# Altium schematic sheet ESP32_main.SchDoc
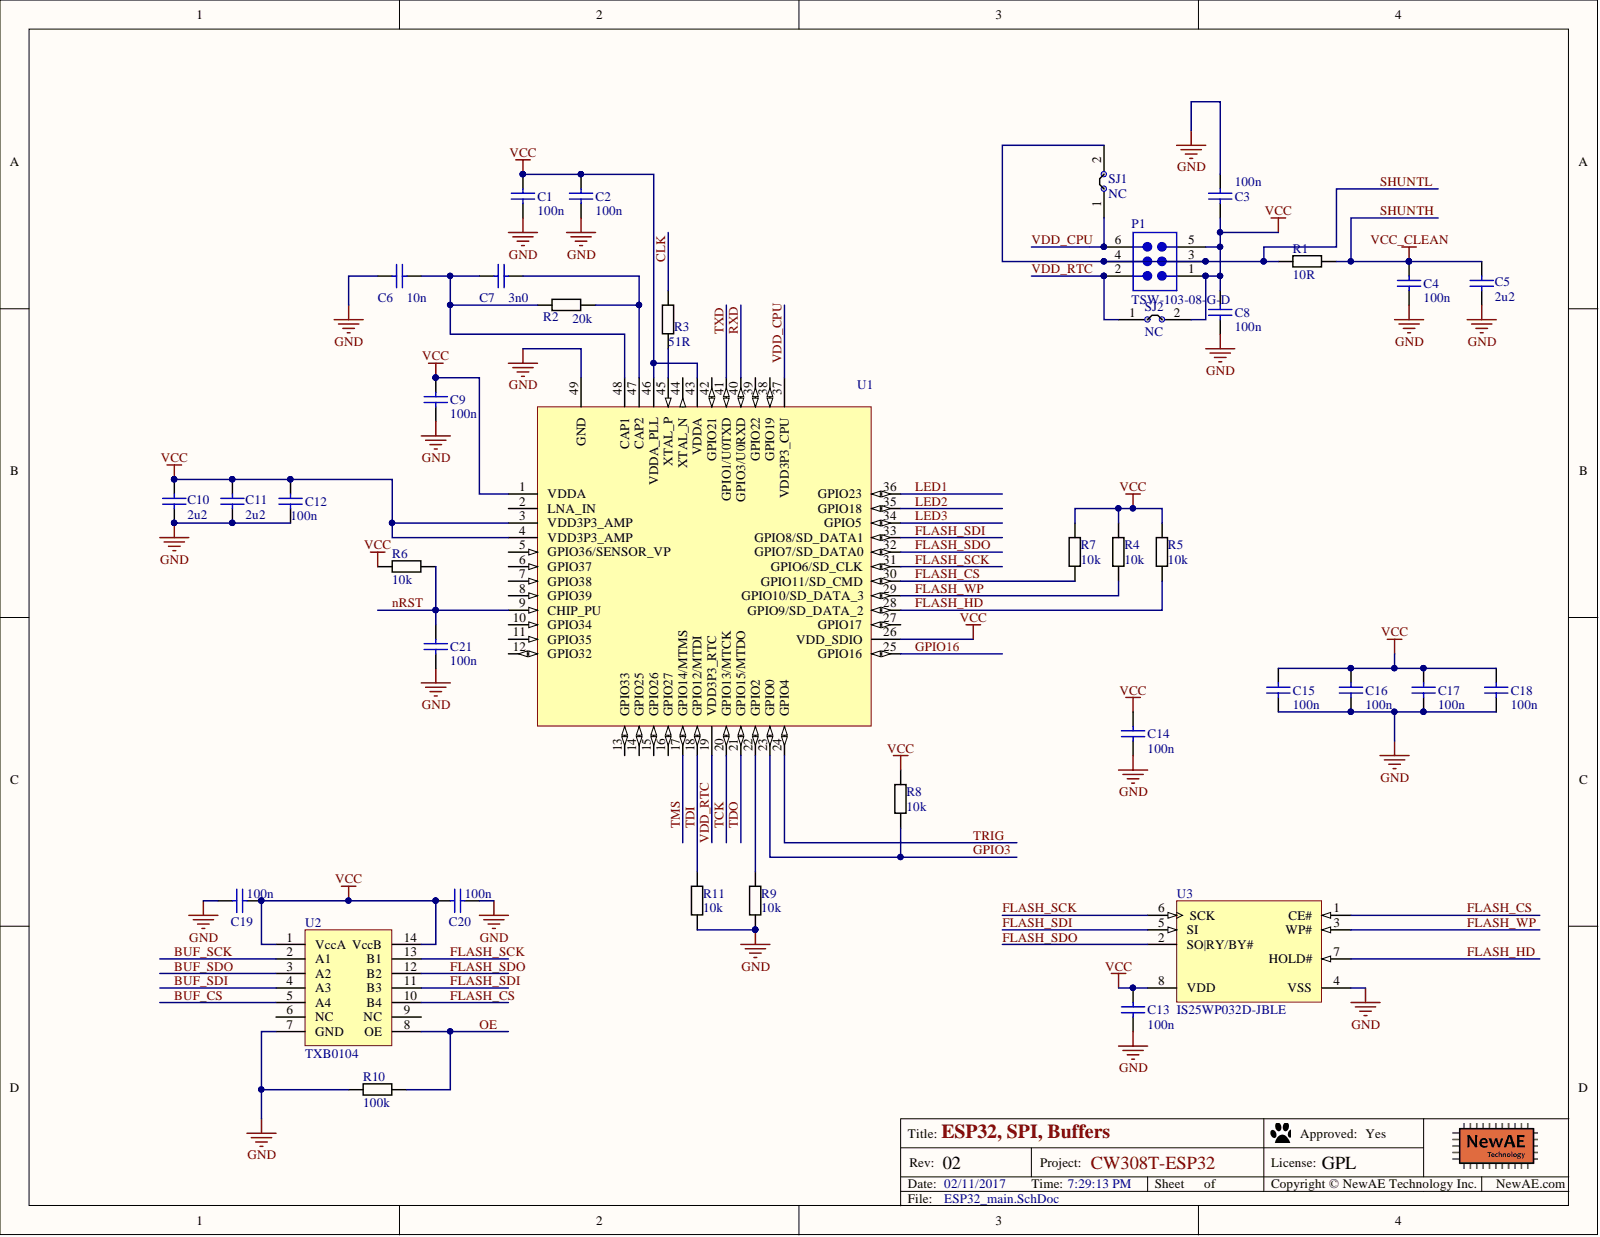
[diagram p0: ESP32_main.SchDoc]

## Parts
C1  100n  [0603]
C2  100n  [0603]
C3  100n  [0603]
C4  100n  [0603]
C5  2u2  [0603]
C6  10n  [0603]
C7  3n0  [0603]
C8  100n  [0603]
C9  100n  [0603]
C10  2u2  [0603]
C11  2u2  [0603]
C12  100n  [0603]
C13  100n  [0603]
C14  100n  [0603]
C15  100n  [0603]
C16  100n  [0603]
C17  100n  [0603]
C18  100n  [0603]
C19  100n  [0603]
C20  100n  [0603]
C21  100n  [0603]
P1  TSW-103-08-G-D  [SMTC-TSW-103-08-X-D]
R1  10R  [0603]
R2  20k  [0603]
R3  51R  [0603]
R4  10k  [0603]
R5  10k  [0603]
R6  10k  [0603]
R7  10k  [0603]
R8  10k  [0603]
R9  10k  [0603]
R10  100k  [0603]
R11  10k  [0603]
SJ1  NC  [SJ_2S_NC]  (no BOM)
SJ2  NC  [SJ_2S_NC]  (no BOM)
U1  ESP32  [QFN48_HAND]  (value not drawn)
U2  TXB0104  [SOIC14 (R-PDSO-G14)]
U3  IS25WP032D-JBLE  [SOIC8_WIDE]

## Nets
GND: C1.2 C2.2 C3.1 C4.2 C5.2 C6.1 C8.2 C9.2 C10.2 C11.2 C12.2 C13.2 C14.2 C15.2 C16.2 C17.2 C18.2 C19.1 C20.2 C21.2 R9.2 R10.1 R11.2 U1.49(GND) U2.7(GND) U3.4(VSS)
VCC: C1.1 C2.1 C3.2 C8.1 C9.1 C10.1 C11.1 C12.1 C13.1 C14.1 C15.1 C16.1 C17.1 C18.1 C19.2 C20.1 P1.1 P1.5 R4.1 R5.1 R6.1 R7.1 R8.1 SJ2.2 U1.1(VDDA) U1.3(VDD3P3_AMP) U1.4(VDD3P3_AMP) U1.26(VDD_SDIO) U1.43(VDDA) U1.46(VDDA_PLL) U2.1(VccA) U2.14(VccB) U3.8(VDD)
BUF_CS: U2.5(A4)
BUF_SCK: U2.2(A1)
BUF_SDI: U2.4(A3)
BUF_SDO: U2.3(A2)
CLK: R3.1
FLASH_CS: R7.2 U1.30(GPIO11/SD_CMD) U2.10(B4) U3.1(CE#)
FLASH_HD: R5.2 U1.28(GPIO9/SD_DATA_2) U3.7(HOLD#)
FLASH_SCK: U1.31(GPIO6/SD_CLK) U2.13(B1) U3.6(SCK)
FLASH_SDI: U1.33(GPIO8/SD_DATA1) U2.11(B3) U3.5(SI)
FLASH_SDO: U1.32(GPIO7/SD_DATA0) U2.12(B2) U3.2(SO|RY/BY#)
FLASH_WP: R4.2 U1.29(GPIO10/SD_DATA_3) U3.3(WP#)
GPIO3: R8.2 U1.23(GPIO0)
GPIO16: U1.25(GPIO16)
LED1: U1.36(GPIO23)
LED2: U1.35(GPIO18)
LED3: U1.34(GPIO5)
nRST: C21.1 R6.2 U1.9(CHIP_PU)
OE: R10.2 U2.8(OE)
RXD: U1.40(GPIO3/U0RXD)
SHUNTH: C4.1 C5.1 R1.2
SHUNTL: P1.3 P1.4 R1.1 SJ1.2
TCK: U1.20(GPIO13/MTCK)
TDI: R11.1 U1.18(GPIO12/MTDI)
TDO: U1.21(GPIO15/MTDO)
TMS: U1.17(GPIO14/MTMS)
TRIG: U1.24(GPIO4)
TXD: U1.41(GPIO1/U0TXD)
VDD_CPU: P1.6 SJ1.1 U1.37(VDD3P3_CPU)
VDD_RTC: P1.2 SJ2.1 U1.19(VDD3P3_RTC)
NetC6_2: C6.2 C7.1 R2.1 U1.48(CAP1)
NetC7_2: C7.2 R2.2 U1.47(CAP2)
NetR3_2: R3.2 U1.45(XTAL_P)
NetR9_1: R9.1 U1.22(GPIO2)

Not connected: U1 2(LNA_IN) 5(GPIO36/SENSOR_VP) 6(GPIO37) 7(GPIO38) 8(GPIO39) 10(GPIO34) 11(GPIO35) 12(GPIO32) 13(GPIO33) 14(GPIO25) 15(GPIO26) 16(GPIO27) 27(GPIO17) 38(GPIO19) 39(GPIO22) 42(GPIO21) 44(XTAL_N); U2 6(NC) 9(NC)

## Library data of the parts
C1-C4 C8 C9 C12-C21 = CCHIP-100n-0603: 100n, 0603; Manufacture 1: Kemet; Manufacture Part Number 1: C0603C104K5RACTU; Case-Metric: 1608; Component Group: Capacitor; Database Table Name: main; Rated Voltage: 50V; Case-EIA: 0603; Component Kind: Standard; Component Type: Standard; Library Name: NewAE_AltiumLib.DBLib; Mounting Technology: SMT; Part Number: CCHIP-100n-0603; Pin Count: 2; Supplier 1: Digi-Key; Supplier Part Number 1: 399-5089-2-ND; Value: 100n
C5 C10 C11 = CCHIP-2u2-0603: 2u2, 0603; Manufacture 1: Samsung; Manufacture Part Number 1: CL10B225KP8NNNC; Case-Metric: 1608; Component Group: Capacitor; Database Table Name: main; Case-EIA: 0603; Component Kind: Standard; Component Type: Standard; Library Name: NewAE_AltiumLib.DBLib; Mounting Technology: SMT; Part Number: CCHIP-2u2-0603; Pin Count: 2; Supplier 1: Digi-Key; Supplier Part Number 1: 1276-1134-2-ND; Value: 2u2
C6 = CCHIP-10n-0603: 10n, 0603; Case-Metric: 1608; Component Group: Capacitor; Database Table Name: main; Case-EIA: 0603; Component Kind: Standard; Component Type: Standard; Library Name: NewAE_AltiumLib.DBLib; Mounting Technology: SMT; Part Number: CCHIP-10n-0603; Pin Count: 2; Value: 10n
C7 = CCHIP-3n0-0603: 3n0, 0603; Case-Metric: 1608; Component Group: Capacitor; Database Table Name: main; Case-EIA: 0603; Component Kind: Standard; Component Type: Standard; Library Name: NewAE_AltiumLib.DBLib; Mounting Technology: SMT; Part Number: CCHIP-3n0-0603; Pin Count: 2; Value: 3n0
P1 = CMP-1024-00338-1: Manufacturer: Samtec; Pitch: 2.54 mm; Component Kind: Standard; ComponentLink1Description: Manufacturer URL; ComponentLink1URL: http://www.samtec.com; ComponentLink2Description: Datasheet; ComponentLink2URL: http://www.samtec.com/documents/webfiles/pdf/TSW_TH.PDF; ComponentLink3Description: Package Specification; ComponentLink3URL: http://www.samtec.com/documents/webfiles/cpdf/TSW-XXX-XX-XXX-X-XX-XXX-MKT.pdf; DatasheetVersion: F-214; Library Name: Altium Content Vault; Mounting Technology: Through-hole; Orientation: Vertical; PackageDescription: .025[0.64] SQ. Post Terminal Strip Assembly; PackageReference: TSW-103-08-X-D; PackageVersion: DM, 8/1988; PartNumber: TSW-103-08-G-D; RoHS: True; Temperature Range: -55 to 125 degC
R1 = RCHIP-10R-0603: 10R res, 0603, 1%, 1/10W; Manufacture 1: Yageo; Manufacture Part Number 1: RC0603FR-0710RL; Case-Metric: 1608; Component Group: Resistor; Database Table Name: main; Rated Power: 0.1W; Rated Voltage: 75VDC; Case-EIA: 0603; Component Kind: Standard; Component Type: Standard; Library Name: NewAE_AltiumLib.DBLib; Mounting Technology: SMT; Part Number: RCHIP-10R-0603; Pin Count: 2; Supplier 1: Digi-Key; Supplier Part Number 1: 311-10.0HRCT-ND; Temperature Range: -55C to 155C; Tolerance: 1%; Value: 10R
R2 = RCHIP-20K-0603: 20k res, 0603, 1%, 1/10W; Manufacture 1: Yageo; Manufacture Part Number 1: RC0603FR-0720KL; Case-Metric: 1608; Component Group: Resistor; Database Table Name: main; Rated Power: 0.1W; Rated Voltage: 75VDC; Case-EIA: 0603; Component Kind: Standard; Component Type: Standard; Library Name: NewAE_AltiumLib.DBLib; Mounting Technology: SMT; Part Number: RCHIP-20K-0603; Pin Count: 2; Supplier 1: Digi-Key; Supplier Part Number 1: 311-20.0KHRCT-ND; Temperature Range: -55C to 155C; Tolerance: 1%; Value: 20k
R3 = RCHIP-51R-0603: 51R res, 0603, 1%, 1/10W; Manufacture 1: Yageo; Manufacture Part Number 1: RC0603FR-0751RL; Case-Metric: 1608; Component Group: Resistor; Database Table Name: main; Rated Power: 0.1W; Rated Voltage: 75VDC; Case-EIA: 0603; Component Kind: Standard; Component Type: Standard; Library Name: NewAE_AltiumLib.DBLib; Mounting Technology: SMT; Part Number: RCHIP-51R-0603; Pin Count: 2; Supplier 1: Digi-Key; Supplier Part Number 1: 311-51.0HRCT-ND; Temperature Range: -55C to 155C; Tolerance: 1%; Value: 51R
R4-R9 R11 = RCHIP-10K-0603: 10k res, 0603, 1%, 1/10W; Manufacture 1: Yageo; Manufacture Part Number 1: RC0603FR-0710KL; Case-Metric: 1608; Component Group: Resistor; Database Table Name: main; Rated Power: 0.1W; Rated Voltage: 75VDC; Case-EIA: 0603; Component Kind: Standard; Component Type: Standard; Library Name: NewAE_AltiumLib.DBLib; Mounting Technology: SMT; Part Number: RCHIP-10K-0603; Pin Count: 2; Supplier 1: Digi-Key; Supplier Part Number 1: 311-10.0KHRCT-ND; Temperature Range: -55C to 155C; Tolerance: 1%; Value: 10k
R10 = RCHIP-100K-0603: 100k res, 0603, 1%, 1/10W; Manufacture 1: Yageo; Manufacture Part Number 1: RC0603FR-07100KL; Case-Metric: 1608; Component Group: Resistor; Database Table Name: main; Rated Power: 0.1W; Rated Voltage: 75VDC; Case-EIA: 0603; Component Kind: Standard; Component Type: Standard; Library Name: NewAE_AltiumLib.DBLib; Mounting Technology: SMT; Part Number: RCHIP-100K-0603; Pin Count: 2; Supplier 1: Digi-Key; Supplier Part Number 1: 311-100KHRCT-ND; Temperature Range: -55C to 155C; Tolerance: 1%; Value: 100k
SJ1 SJ2 = SJ_2S: Solder Jumper, NC; Component Group: PCB Feature; Database Table Name: main; Component Type: Standard (No BOM); Library Name: NewAE_AltiumLib.DBLib; Mounting Technology: COPPER; Part Number: SJ_2S; Pin Count: 2
U1 = ESP32: Dual-Core WiFi/Bluetooth Chip; Component Group: Digital IC; Database Table Name: main; Component Kind: Standard; Component Type: Standard; Library Name: NewAE_AltiumLib.DBLib; Mounting Technology: SMT; Part Number: ESP32; Pin Count: 48
U2 = TXB0104DR: IC 4BIT NON-INV TRANSLTR 14-SOIC; Manufacture 1: Texas Instruments; Component Group: Digital IC; Database Table Name: main; PP Material Stack: 0; Component Kind: Standard; Component Type: Standard; Library Name: NewAE_AltiumLib.DBLib; Manufacture Part Number 1: TXB0104DR; Mounting Technology: SMT; Part Number: TXB0104DR; Pin Count: 14; PP Rotation: 0; RoHS: Yes; Supplier 1: Digi-Key; Supplier Part Number 1: 296-21928-1-ND
U3 = IS25WP032D-JBLE: 32MB QPI/QPI/QSPI 8-PIN SOP 208M; Manufacture 1: ISSI; Component Group: Digital IC; Database Table Name: main; Pitch: 1.27mm; Component Kind: Standard; Component Type: Standard; Library Name: NewAE_AltiumLib.DBLib; Manufacture Part Number 1: IS25WP032D-JBLE; Mounting Technology: SMT; Part Number: IS25WP032D-JBLE; Pin Count: 8; RoHS: Yes; Supplier 1: Digi-Key; Supplier Part Number 1: 706-1615-ND
